# Altium schematic sheet Module 4G.SchDoc("Module 4G")
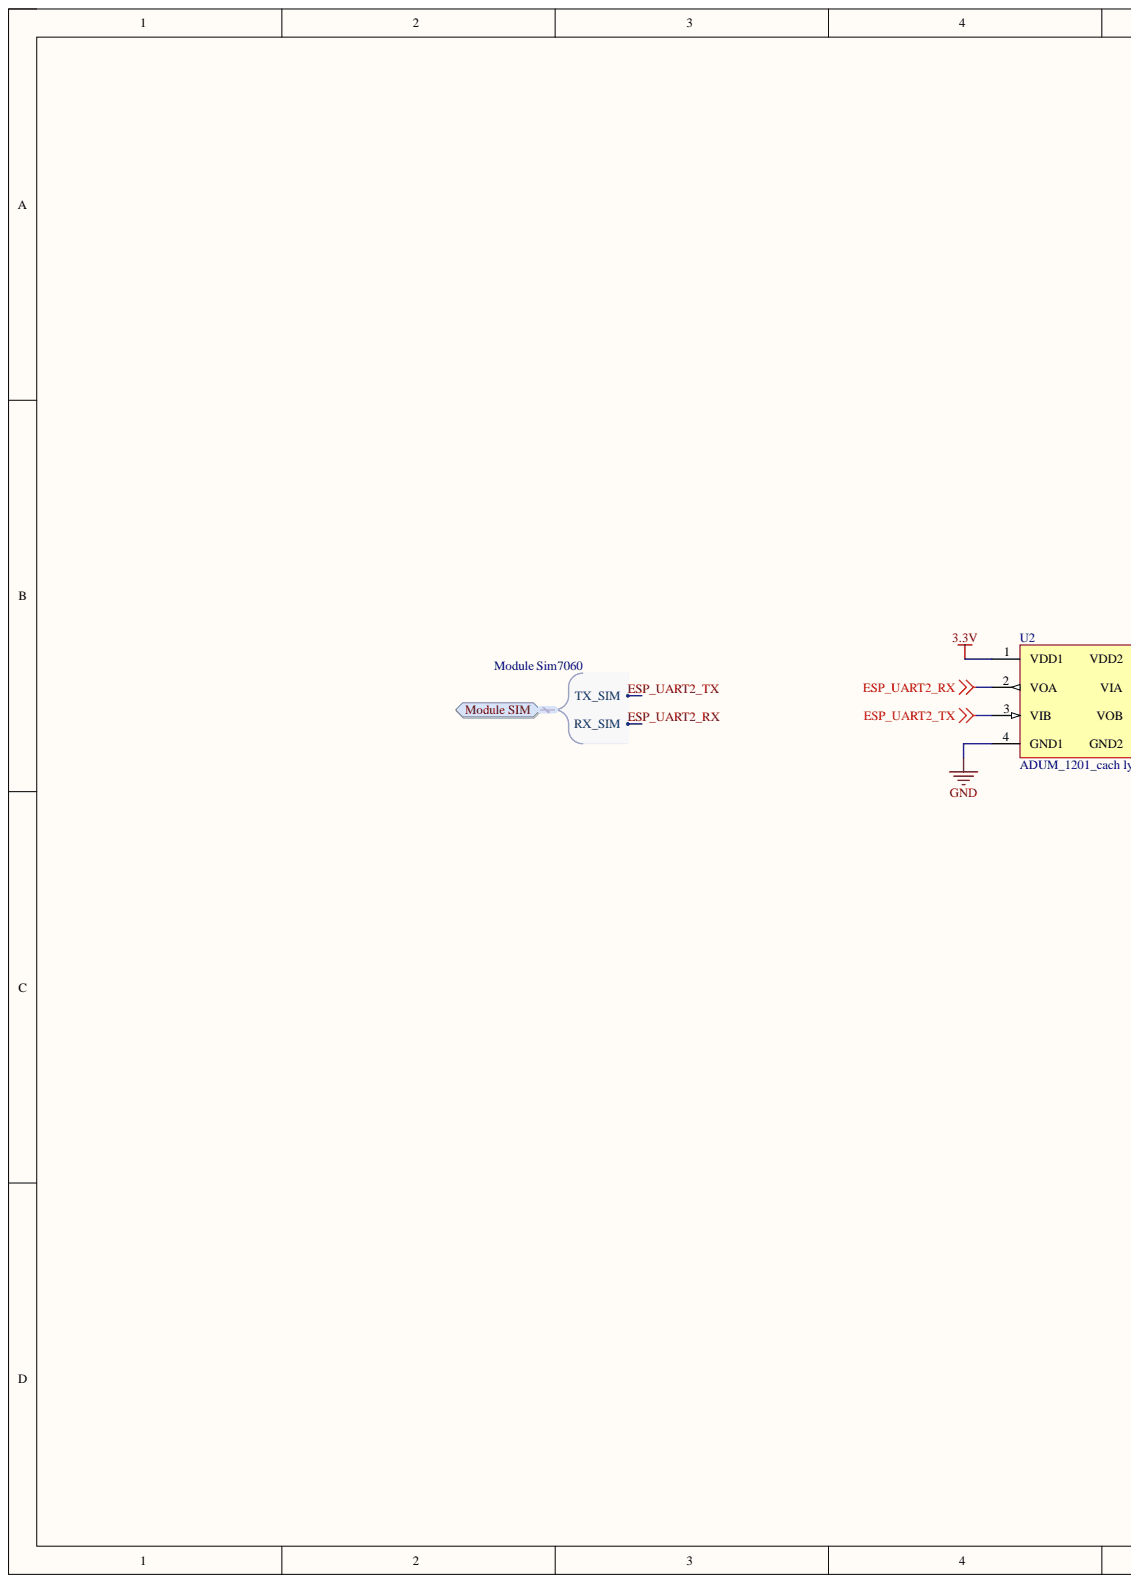
[diagram p0: Module 4G.SchDoc, left]
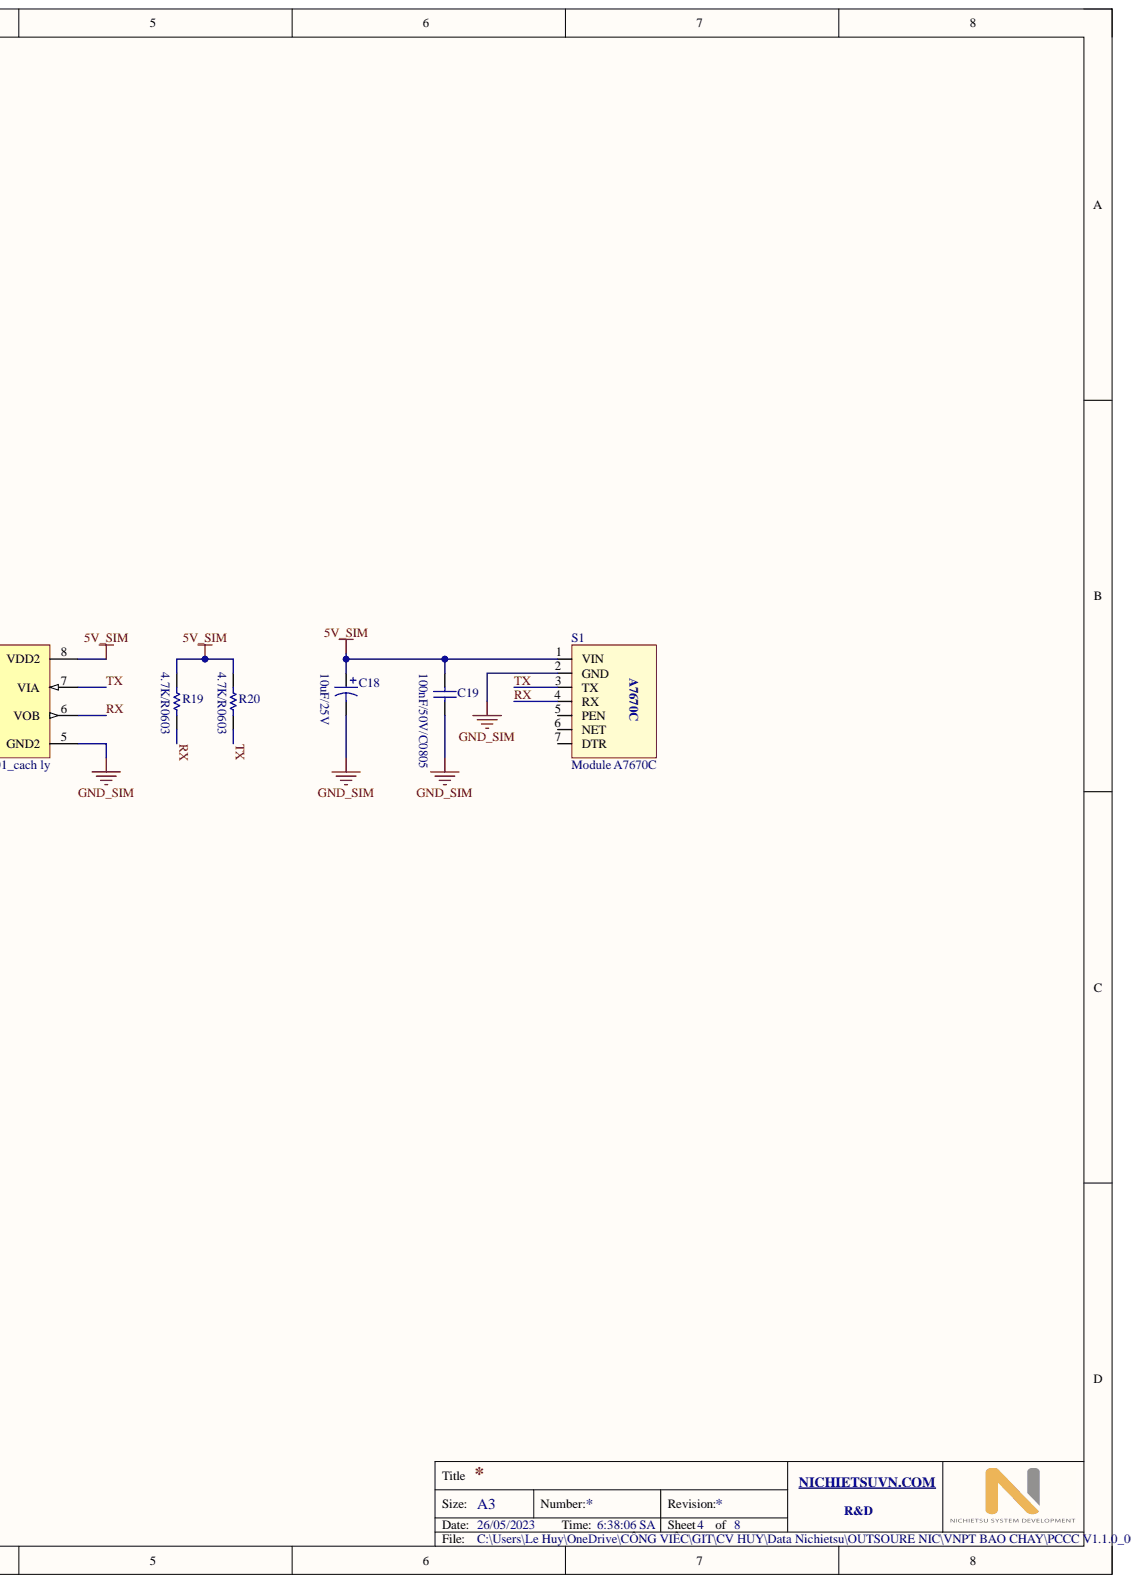
[diagram p1: Module 4G.SchDoc, right]

## Parts
C18  10uF/25V  [CAP TANT SIZE B]
C19  100nF/50V/C0805  [C0805]
R19  4.7K/R0603  [R0603]
R20  4.7K/R0603  [R0603]
S1  Module A7670C  [Module A7670C]
U2  ADUM_1201_cach ly  [ADUM1201]

## Ports
Module SIM, Module SIM.RX_SIM, Module SIM.TX_SIM

## Nets
3.3V: U2.1(VDD1)
5V_SIM: C18.1 C19.2 R19.2 R20.2 S1.1(VIN) U2.8(VDD2)
GND: U2.4(GND1)
GND_SIM: C18.2 C19.1 S1.2(GND) U2.5(GND2)
ESP_UART2_RX: U2.2(VOA) ; port Module SIM
ESP_UART2_TX: U2.3(VIB) ; port Module SIM
RX: R19.1 S1.4(RX) U2.6(VOB)
TX: R20.1 S1.3(TX) U2.7(VIA)

Not connected: S1 5(PEN) 6(NET) 7(DTR)

## Library data of the parts
C18 = 10uF/25V/SIZE B/10%: CAP TANT 10UF 25V 10% 1411; Manufacturer: KEMET; Manufacturer Part Number: T491B106K025AT; Manufacturer Size Code: B; Capacitance: 10µF; ESR (Equivalent Series Resistance): 3 Ohm; Lifetime @ Temp.: 2000 Hrs @ 85°C; Type: Molded; Category: Capacitors; Component Kind: Standard; ComponentLink1Description: http://www.kemet.com/Lists/ProductCatalog/Attachments/254/KEM_T2005_T491.pdf; ComponentLink1URL: http://www.kemet.com/Lists/ProductCatalog/Attachments/254/KEM_T2005_T491.pdf; Failure Rate: -; Features: General Purpose; Height - Seated (Max): 0.083" (2.10mm); Lead Spacing: -; Mounting Type: Surface Mount; Operating Temperature: -55°C ~ 125°C; Package / Case: 1411 (3528 Metric); Packaging: Cut Tape (CT); Part Status: Active; Pricing 1: 1=1.11, 10=0.842, 25=0.7448, 50=0.6994, 100=0.6022, 250=0.54392, 500=0.46622, 1000=0.40147 (USD); RoHS: RoHS Compliant; Size / Dimension: 0.138" L x 0.110" W (3.50mm x 2.80mm); Stock 1: 74222; Supplier 1: Digi-Key; Supplier Part Number 1: 399-5125-1-ND; Tolerance: ±10%; Voltage - Rated: 25V; Library Name: Manh_Capacitor.SchLib
C19 = 0.1uF/50V/C0805/10%: CAP CER 0.1UF 50V 10% X7R 0805; Manufacturer: Samsung Electro-Mechanics America, Inc.; Manufacturer Part Number: CL21B104KBCNNNC; Capacitance: 0.1µF; Applications: General Purpose; Category: Capacitors; Component Kind: Standard; ComponentLink1Description: http://www.samsungsem.com/kr/front/downloadcms.do?path=/kr/support/product-search/mlcc/__icsFiles/afieldfile/2015/04/16&fileName=CL21B104KBCNNNC.pdf; ComponentLink1URL: http://www.samsungsem.com/kr/front/downloadcms.do?path=/kr/support/product-search/mlcc/__icsFiles/afieldfile/2015/04/16&fileName=CL21B104KBCNNNC.pdf; Features: -; Height - Seated (Max): -; Lead Spacing: -; Lead Style: -; Mounting Type: Surface Mount, MLCC; Operating Temperature: -55°C ~ 125°C; Package / Case: 0805 (2012 Metric); Packaging: Cut Tape (CT); Ratings: -; RoHS: RoHS Compliant; Size / Dimension: 0.079" L x 0.049" W (2.00mm x 1.25mm); Supplier 1: Digi-Key; Supplier Part Number 1: 1276-1003-1-ND; Temperature Coefficient: X7R; Thickness (Max): 0.037" (0.95mm); Tolerance: ±10%; Voltage - Rated: 50V; Library Name: Manh_Capacitor.SchLib
R19 R20 = 4.7K/R0603/1%: RES SMD 4.7K OHM 1% 1/10W 0603; Manufacturer: Yageo; Manufacturer Part Number: RC0603FR-074K7L; Power (Watts): 0.1W, 1/10W; Temperature Coefficient: ±100ppm/°C; Category: Resistors; Component Kind: Standard; ComponentLink1Description: http://www.yageo.com/NewPortal/yageodocoutput?fileName=/pdf/R-Chip/PYu-RC_Group_51_RoHS_L_3.pdf; ComponentLink1URL: http://www.yageo.com/NewPortal/yageodocoutput?fileName=/pdf/R-Chip/PYu-RC_Group_51_RoHS_L_3.pdf; Composition: Thick Film; Features: Moisture Resistant; Height: 0.022" (0.55mm); Number of Terminations: 2; Operating Temperature: -55°C ~ 155°C; Package / Case: 0603 (1608 Metric); Packaging: Cut Tape (CT); Pricing 1: 1=0.1, 10=0.014, 25=0.01, 100=0.0057, 250=0.00436, 500=0.00348, 1000=0.00257, 2500=0.00223 (USD); Resistance (Ohms): 4.7k; RoHS: RoHS Compliant; Size / Dimension: 0.063" L x 0.031" W (1.60mm x 0.80mm); Stock 1: 3295420; Supplier 1: Digi-Key; Supplier Device Package: 0603; Supplier Part Number 1: 311-4.70KHRCT-ND; Tolerance: ±1%; Library Name: Manh_Resistor.SchLib
S1 = Module A7670C: Component Kind: Standard; ComponentLink1Description: Buy; ComponentLink1URL: https://linhkienthuduc.com/module-4g-simcom-a7670cas-da-ra-chan-thay-the-module-sim800l?fbclid=IwAR1G5wYYUv7p81VrcWdIp5blH95Ph3Cg0YzZirZTlKBqqVU0n-BOBnrUa-4; Library Name: Nichietsuvn.SchLib
U2 = ADUM_1201_cach ly: Component Kind: Standard; Library Name: All Library.SchLib
